# Altium schematic sheet power_main_connector.SchDoc(power_main_connector)
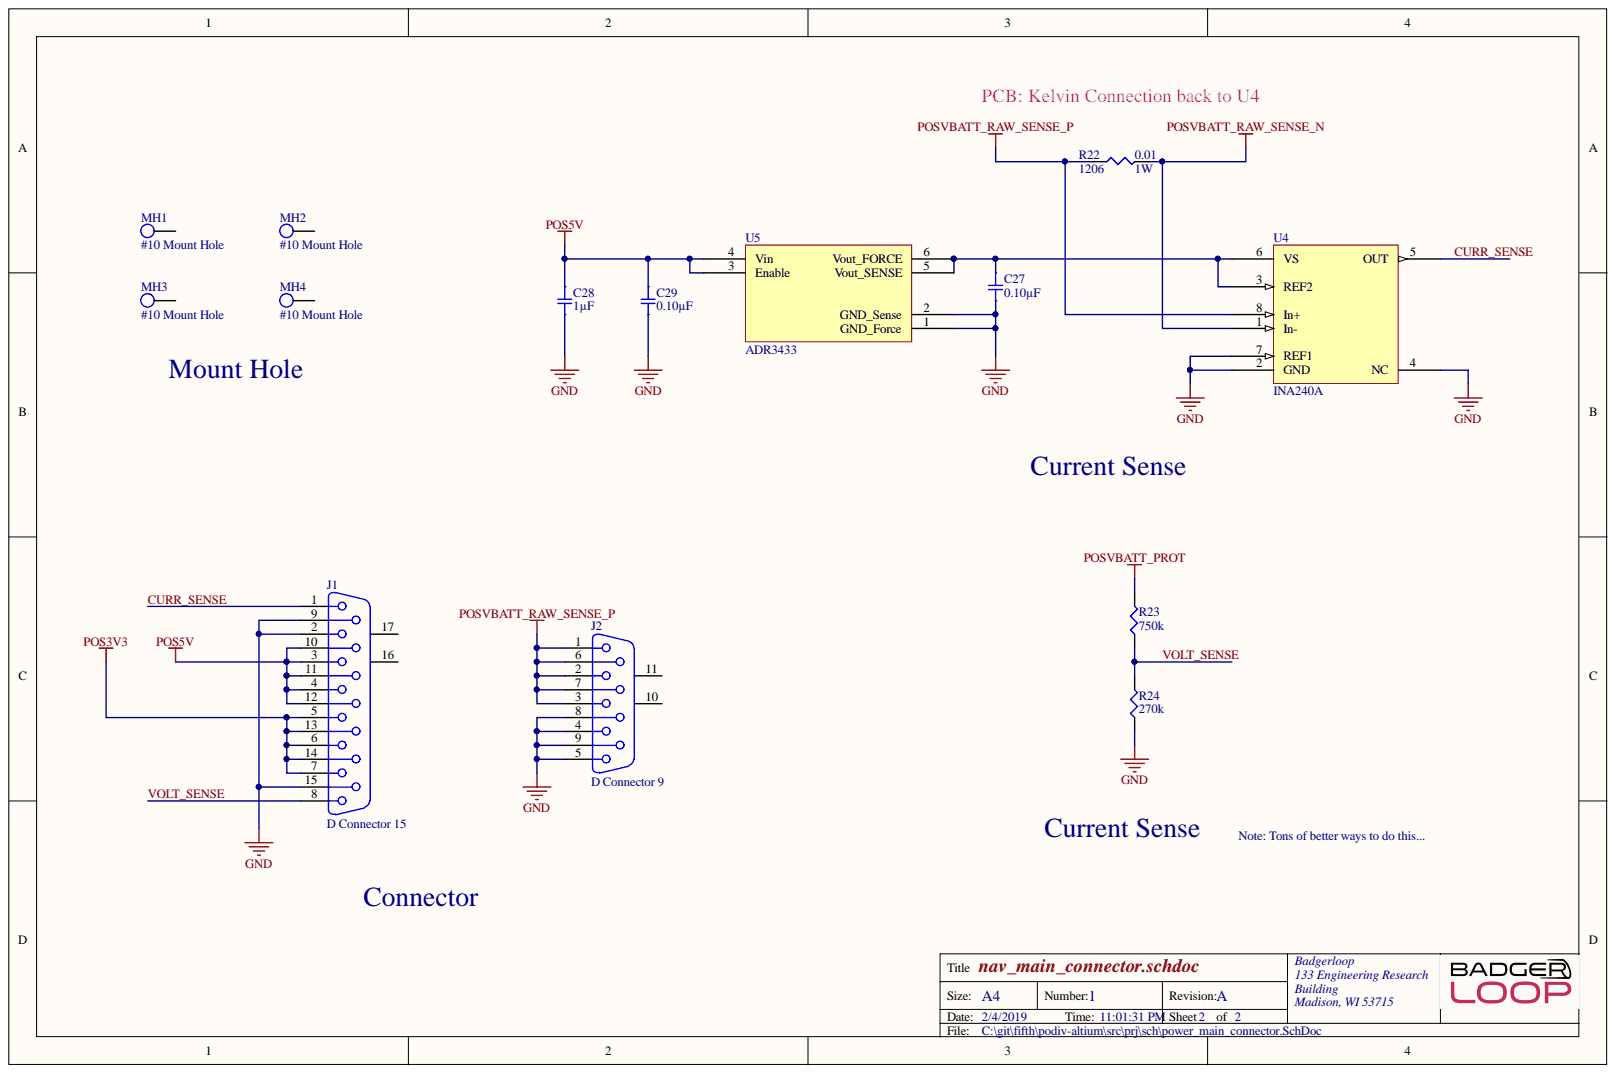
[diagram p0: power_main_connector.SchDoc]

## Parts
C27  0.10µF  [SMD-0805C]
C28  1µF  [SMD-0805C]
C29  0.10µF  [SMD-0805C]
J1  D Connector 15  [DSUB1.385-2H15]
J2  D Connector 9  [DSUB1.385-2H9]
MH1  #10 Mount Hole  [MH]
MH2  #10 Mount Hole  [MH]
MH3  #10 Mount Hole  [MH]
MH4  #10 Mount Hole  [MH]
R22  0.01  Supplier Device Package: 1206  Power (Watts): 1W  [SMD-1206-RES]
R23  750k  [SMD-0805-RES]
R24  270k  [SMD-0805-RES]
U4  INA240A  [SOIC127P600X175-8N]
U5  ADR3433  [SOT95P280X145-6N]

## Nets
GND: C27.2 C28.2 C29.2 J1.2 J1.9 J1.15 J2.4 J2.5 J2.8 J2.9 R24.1 U4.2(GND) U4.4(NC) U4.7(REF1) U5.1(GND_Force) U5.2(GND_Sense)
POS3V3: J1.5 J1.6 J1.7 J1.13 J1.14
POS5V: C28.1 C29.1 J1.3 J1.4 J1.10 J1.11 J1.12 U5.3(Enable) U5.4(Vin)
POSVBATT_PROT: R23.2
POSVBATT_RAW_SENSE_N: R22.2 U4.1(In-)
POSVBATT_RAW_SENSE_P: J2.1 J2.2 J2.3 J2.6 J2.7 R22.1 U4.8(In+)
CURR_SENSE: J1.1 U4.5(OUT)
VOLT_SENSE: J1.8 R23.1 R24.2
NetC27_1: C27.1 U4.3(REF2) U4.6(VS) U5.5(Vout_SENSE) U5.6(Vout_FORCE)

Not connected: J1 16 17; J2 10 11; MH1 46; MH2 46; MH3 46; MH4 46

## Library data of the parts
C27 C29 = GCD21BR71H104KA01L: CAP CER 0.1UF 50V X7R 0805; Manufacturer: Murata Electronics North America; Unit Price (USD): 0.24; Tolerance: ±10%; Minimum Quantity: 1; Factory Stock: 0; Voltage: 50V; Value: 0.10µF; Thickness (Max): 0.049" (1.25mm); Temperature Coefficient: X7R; Size / Dimension: 0.079" L x 0.049" W (2.00mm x 1.25mm); Series: GCD; Ratings: AEC-Q200; Quantity Available: 157; Part Status: Active; Part Number: GCD21BR71H104KA01L; Packaging: Cut Tape (CT); Package / Case: 0805 (2012 Metric); Operating Temperature: -55°C ~ 125°C; Mounting Type: Surface Mount, MLCC; Library Name: capacitor.SchLib; Lead Style: -; Lead Spacing: -; Image: //media.digikey.com/Renders/Murata%20Renders/0805%28GxM6%29.jpg; Height - Seated (Max): -; Features: -; Digi-Key Part Number: 490-11955-1-ND; Datasheets: http://www.murata.com/~/media/webrenewal/support/library/catalog/products/capacitor/mlcc/c03e.ashx; Component Kind: Standard; Applications: Automotive; @ qty: 0
C28 = GCJ21BR71H105KA01L: CAP CER 1UF 50V X7R 0805; Manufacturer: Murata Electronics North America; Unit Price (USD): 0.33; Tolerance: ±10%; Minimum Quantity: 1; Factory Stock: 0; Voltage: 50V; Value: 1µF; Thickness (Max): 0.057" (1.45mm); Temperature Coefficient: X7R; Size / Dimension: 0.079" L x 0.049" W (2.00mm x 1.25mm); Series: GCJ; Ratings: AEC-Q200; Quantity Available: 55137; Part Status: Active; Part Number: GCJ21BR71H105KA01L; Packaging: Cut Tape (CT); Package / Case: 0805 (2012 Metric); Operating Temperature: -55°C ~ 125°C; Mounting Type: Surface Mount, MLCC; Library Name: capacitor.SchLib; Lead Style: -; Lead Spacing: -; Image: //media.digikey.com/Renders/Murata%20Renders/0805%20(2012%20Metric)%20.85mm.jpg; Height - Seated (Max): -; Features: Soft Termination; Digi-Key Part Number: 490-10667-1-ND; Datasheets: http://www.murata.com/~/media/webrenewal/support/library/catalog/products/capacitor/mlcc/c03e.ashx; Component Kind: Standard; Applications: Automotive, Boardflex Sensitive; @ qty: 0
J1 = D Connector 15: Receptacle Assembly, 15 Position, Right Angle; Positions: 15; PackageDocument: O; Signal Integrity: Connector; Published: 15-Jun-2001; PackageReference: 788757; Orientation: Right Angle; Library Name: connector.SchLib; LatestRevisionNote: Re-released for DXP Platform.; LatestRevisionDate: 17-Jul-2002; Gender: Receptacle; DatasheetDocument: 1-Sep-99; Component Kind: Standard; Publisher: Altium Limited
J2 = D Connector 9: Receptacle Assembly, 9 Position, Right Angle; Positions: 9; PackageDocument: O; Signal Integrity: Connector; Published: 15-Jun-2001; PackageReference: 788750; Orientation: Right Angle; Library Name: connector.SchLib; LatestRevisionNote: Re-released for DXP Platform.; LatestRevisionDate: 17-Jul-2002; Gender: Receptacle; DatasheetDocument: 1-Sep-1999; Component Kind: Standard; Publisher: Altium Limited
R22 = ERJ-8CWFR010V: RES SMD 0.01 OHM 1% 1W 1206; Manufacturer: Panasonic Electronic Components; Unit Price (USD): 0.12302; Temperature Coefficient: ±75ppm/°C; Minimum Quantity: 1; Factory Stock: 0; @ qty: 1500; Value: 0.01; Tolerance: ±1%; Size / Dimension: 0.126" L x 0.063" W (3.20mm x 1.60mm); Series: ERJ; Quantity Available: 2; Part Status: Active; Part Number: ERJ-8CWFR010V; Packaging: Cut Tape (CT); Package / Case: 1206 (3216 Metric); Operating Temperature: -55°C ~ 155°C; Number of Terminations: 2; Library Name: resistor.SchLib; Image: //media.digikey.com/Renders/Panasonic%20Renders/ERJ-1206%20Pkg.jpg; Height - Seated (Max): 0.030" (0.75mm); Features: Automotive AEC-Q200, Current Sense; Failure Rate: -; Digi-Key Part Number: P0.01BVCT-ND; Datasheets: https://industrial.panasonic.com/cdbs/www-data/pdf/RDA0000/AOA0000C239.pdf; Composition: Thick Film; Component Kind: Standard
R23 = ERJ-6GEYJ754V: RES SMD 750K OHM 5% 1/8W 0805; Manufacturer: Panasonic Electronic Components; Unit Price (USD): 0.00496; Temperature Coefficient: ±200ppm/°C; Power (Watts): 0.125W, 1/8W; Minimum Quantity: 1; Factory Stock: 0; @ qty: 1500; Value: 750k; Tolerance: ±5%; Supplier Device Package: 0805; Size / Dimension: 0.079" L x 0.049" W (2.00mm x 1.25mm); Series: ERJ; Quantity Available: 45411; Part Status: Active; Part Number: ERJ-6GEYJ754V; Packaging: Cut Tape (CT); Package / Case: 0805 (2012 Metric); Operating Temperature: -55°C ~ 155°C; Number of Terminations: 2; Library Name: resistor.SchLib; Image: //media.digikey.com/Renders/Panasonic%20Renders/ERJ-0805%20Pkg.jpg; Height - Seated (Max): 0.028" (0.70mm); Features: Automotive AEC-Q200; Failure Rate: -; Digi-Key Part Number: P750KACT-ND; Datasheets: http://industrial.panasonic.com/www-cgi/jvcr13pz.cgi?E+PZ+3+AOA0001+ERJ6GEYJ754V+7+WW; Composition: Thick Film; Component Kind: Standard
R24 = ERJ-6GEYJ274V: RES SMD 270K OHM 5% 1/8W 0805; Manufacturer: Panasonic Electronic Components; Unit Price (USD): 0.00496; Temperature Coefficient: ±200ppm/°C; Power (Watts): 0.125W, 1/8W; Minimum Quantity: 1; Factory Stock: 0; @ qty: 1500; Value: 270k; Tolerance: ±5%; Supplier Device Package: 0805; Size / Dimension: 0.079" L x 0.049" W (2.00mm x 1.25mm); Series: ERJ; Quantity Available: 55427; Part Status: Active; Part Number: ERJ-6GEYJ274V; Packaging: Cut Tape (CT); Package / Case: 0805 (2012 Metric); Operating Temperature: -55°C ~ 155°C; Number of Terminations: 2; Library Name: resistor.SchLib; Image: //media.digikey.com/Renders/Panasonic%20Renders/ERJ-0805%20Pkg.jpg; Height - Seated (Max): 0.028" (0.70mm); Features: Automotive AEC-Q200; Failure Rate: -; Digi-Key Part Number: P270KACT-ND; Datasheets: http://industrial.panasonic.com/www-cgi/jvcr13pz.cgi?E+PZ+3+AOA0001+ERJ6GEYJ274V+7+WW; Composition: Thick Film; Component Kind: Standard
U4 = INA240A: IC AMP CURRENT SENSE 8-TSSOP; Manufacturer P/N: INA240A1PWR; Manufacturer: TI; Library Name: ic.SchLib; Digikey P/N: 296-45088-1-ND; Digikey Link: https://www.digikey.com/product-detail/en/texas-instruments/INA240A1PWR/296-45088-1-ND/6562101; Component Kind: Standard
U5 = ADR3433: IC VREF SERIES 3.3V SOT23-6; Manufacturer P/N: ADR3433ARJZ-R7; Manufacturer: Analog Devices; Library Name: ic.SchLib; Digikey P/N: ADR3433ARJZ-R7CT-ND; Digikey Link: https://www.digikey.com/product-detail/en/analog-devices-inc/ADR3433ARJZ-R7/ADR3433ARJZ-R7CT-ND/4909083; ComponentLink1URL: https://www.digikey.com/product-detail/en/analog-devices-inc/ADR3433ARJZ-R7/ADR3433ARJZ-R7CT-ND/4909083; ComponentLink1Description: Digikey; Component Kind: Standard
MH1-MH4 = #10 Mount Hole: Library Name: misc.SchLib; Component Kind: Standard
